# Altium schematic sheet Logic.SchDoc(Logic)
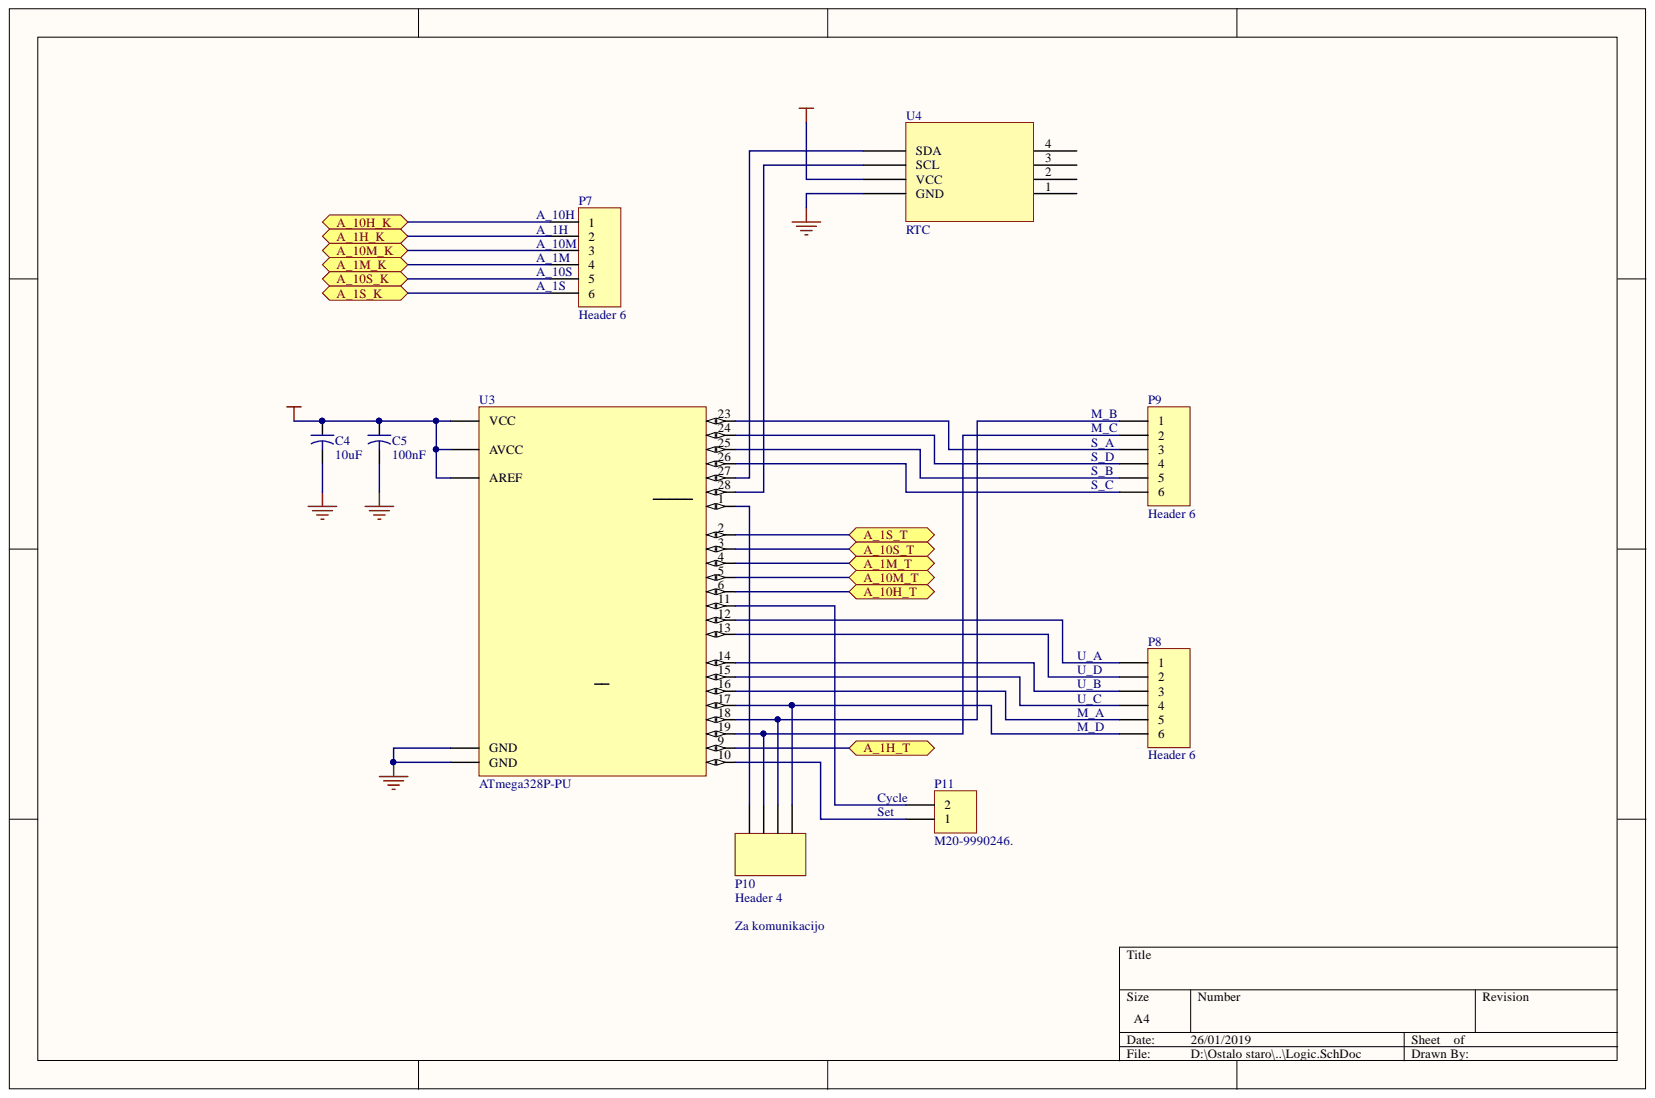
[diagram p0: Logic.SchDoc]

## Parts
C4  value 10uF  [CAPPR2-5x7]  (hidden comment: Electrolytic capacitor)
C5  value 100nF  [CAPPR2-5x7]  (hidden comment: Electrolytic capacitor)
P7  Header 6  [HDR1X6]
P8  Header 6  [HDR1X6]
P9  Header 6  [HDR1X6]
P10  Header 4  [HDR1X4]
P11  M20-9990246.  [HDR 1X2 2.54 M]
U3  ATmega328P-PU  [28P3]
U4  RTC  [PCBComponent_1]

## Ports
A_1H_K, A_1H_T, A_1M_K, A_1M_T, A_1S_K, A_1S_T, A_10H_K, A_10H_T, A_10M_K, A_10M_T, A_10S_K, A_10S_T

## Nets
5V: C4.1 C5.1 U3.7(VCC) U3.20(AVCC) U3.21(AREF) U4.6(VCC)
GND: C4.2 C5.2 U3.8(GND) U3.22(GND) U4.5(GND)
A_1H_K: P7.2 ; port A_1H_K
A_1H_T: U3.9 ; port A_1H_T
A_1M_K: P7.4 ; port A_1M_K
A_1M_T: U3.4 ; port A_1M_T
A_1S_K: P7.6 ; port A_1S_K
A_1S_T: U3.2 ; port A_1S_T
A_10H_K: P7.1 ; port A_10H_K
A_10H_T: U3.6 ; port A_10H_T
A_10M_K: P7.3 ; port A_10M_K
A_10M_T: U3.5 ; port A_10M_T
A_10S_K: P7.5 ; port A_10S_K
A_10S_T: U3.3 ; port A_10S_T
NetP8_1: P8.1 U3.12
NetP8_2: P8.2 U3.13
NetP8_3: P8.3 U3.14
NetP8_4: P8.4 U3.15
NetP8_5: P8.5 U3.16
NetP8_6: P8.6 P10.1 U3.17
NetP9_1: P9.1 P10.2 U3.18
NetP9_2: P9.2 P10.3 U3.19
NetP9_3: P9.3 U3.23
NetP9_4: P9.4 U3.24
NetP9_5: P9.5 U3.25
NetP9_6: P9.6 U3.26
NetP10_4: P10.4 U3.1
NetP11_1: P11.1 U3.10
NetP11_2: P11.2 U3.11
NetU3_27: U3.27 U4.8(SDA)
NetU3_28: U3.28 U4.7(SCL)

Not connected: U4 1 2 3 4

## Library data of the parts
C4 C5 = C El Rad 2 5x7: Capacitor; Signal Integrity: Model Name; Library Name: Capacitors.IntLib; Component Kind: Standard
P7-P9 = Header 6: Header, 6-Pin; Signal Integrity: Connector; Library Name: Miscellaneous Connectors.IntLib; LatestRevisionNote: Re-released for DXP Platform.; LatestRevisionDate: 17-Jul-2002; Component Kind: Standard; Publisher: Altium Limited
P10 = Header 4: Header, 4-Pin; Signal Integrity: Connector; Library Name: Miscellaneous Connectors.IntLib; LatestRevisionNote: Re-released for DXP Platform.; LatestRevisionDate: 17-Jul-2002; Component Kind: Standard; Publisher: Altium Limited
P11 = M20-9990246.: HEADER, STRAIGHT, 2.54MM 1ROW, 2WAY; Manufacturer: HARWIN; Supplier Part Number 1: 1022247; Supplier 1: Farnell; Stock 1: 41292; RoHS: YES; Pricing 1: 10=0,0618, 50=0,0562, 100=0,0485, 250=0,0468, 500=0,0353, 1000=0,032, 2500=0,0251, 5000=0,0245 (EUR); Manufacturer Part Number: M20-9990246.; Library Name: Connectors.IntLib; ComponentLink3URL: http://www.harwin.com/include/downloads/drawings/M20-999.PDF; ComponentLink3Description: http://www.harwin.com/include/downloads/drawings/M20-999.PDF; ComponentLink2URL: http://www.farnell.com/cad/315376.pdf; ComponentLink2Description: http://www.farnell.com/cad/315376.pdf; ComponentLink1URL: http://www.farnell.com/datasheets/1089605.pdf; ComponentLink1Description: http://www.farnell.com/datasheets/1089605.pdf; Component Kind: Standard
U3 = ATmega328P-PU: 8-bit AVR Microcontroller, 32KB Flash, 1KB EEPROM, 2KB SRAM, 28-pin PDIP, Industrial Grade (-40°C to 85°C); PackageVersion: revB, Sep-2001; PackageReference: 28P3; PackageDescription: 28-lead (0.300"/7.62mm Wide) Plastic Dual Inline Package (PDIP); Library Name: Atmel Microcontroller megaAVR.IntLib; DatasheetVersion: revD, May-2011; ComponentLink3URL: http://www.atmel.com/dyn/resources/prod_documents/8271S.pdf; ComponentLink3Description: ATmega48A/48PA/88A/88PA/168A/168PA/328/328P Summary; ComponentLink2URL: http://www.atmel.com/dyn/resources/prod_documents/8271.pdf; ComponentLink2Description: ATmega48A/48PA/88A/88PA/168A/168PA/328/328P Datasheet; ComponentLink1URL: http://www.atmel.com/; ComponentLink1Description: Manufacturer URL; Component Kind: Standard
U4 = Component_1: Library Name: rtc2.SchLib; Component Kind: Standard
